# Altium schematic sheet Trigger.SchDoc(Trigger)
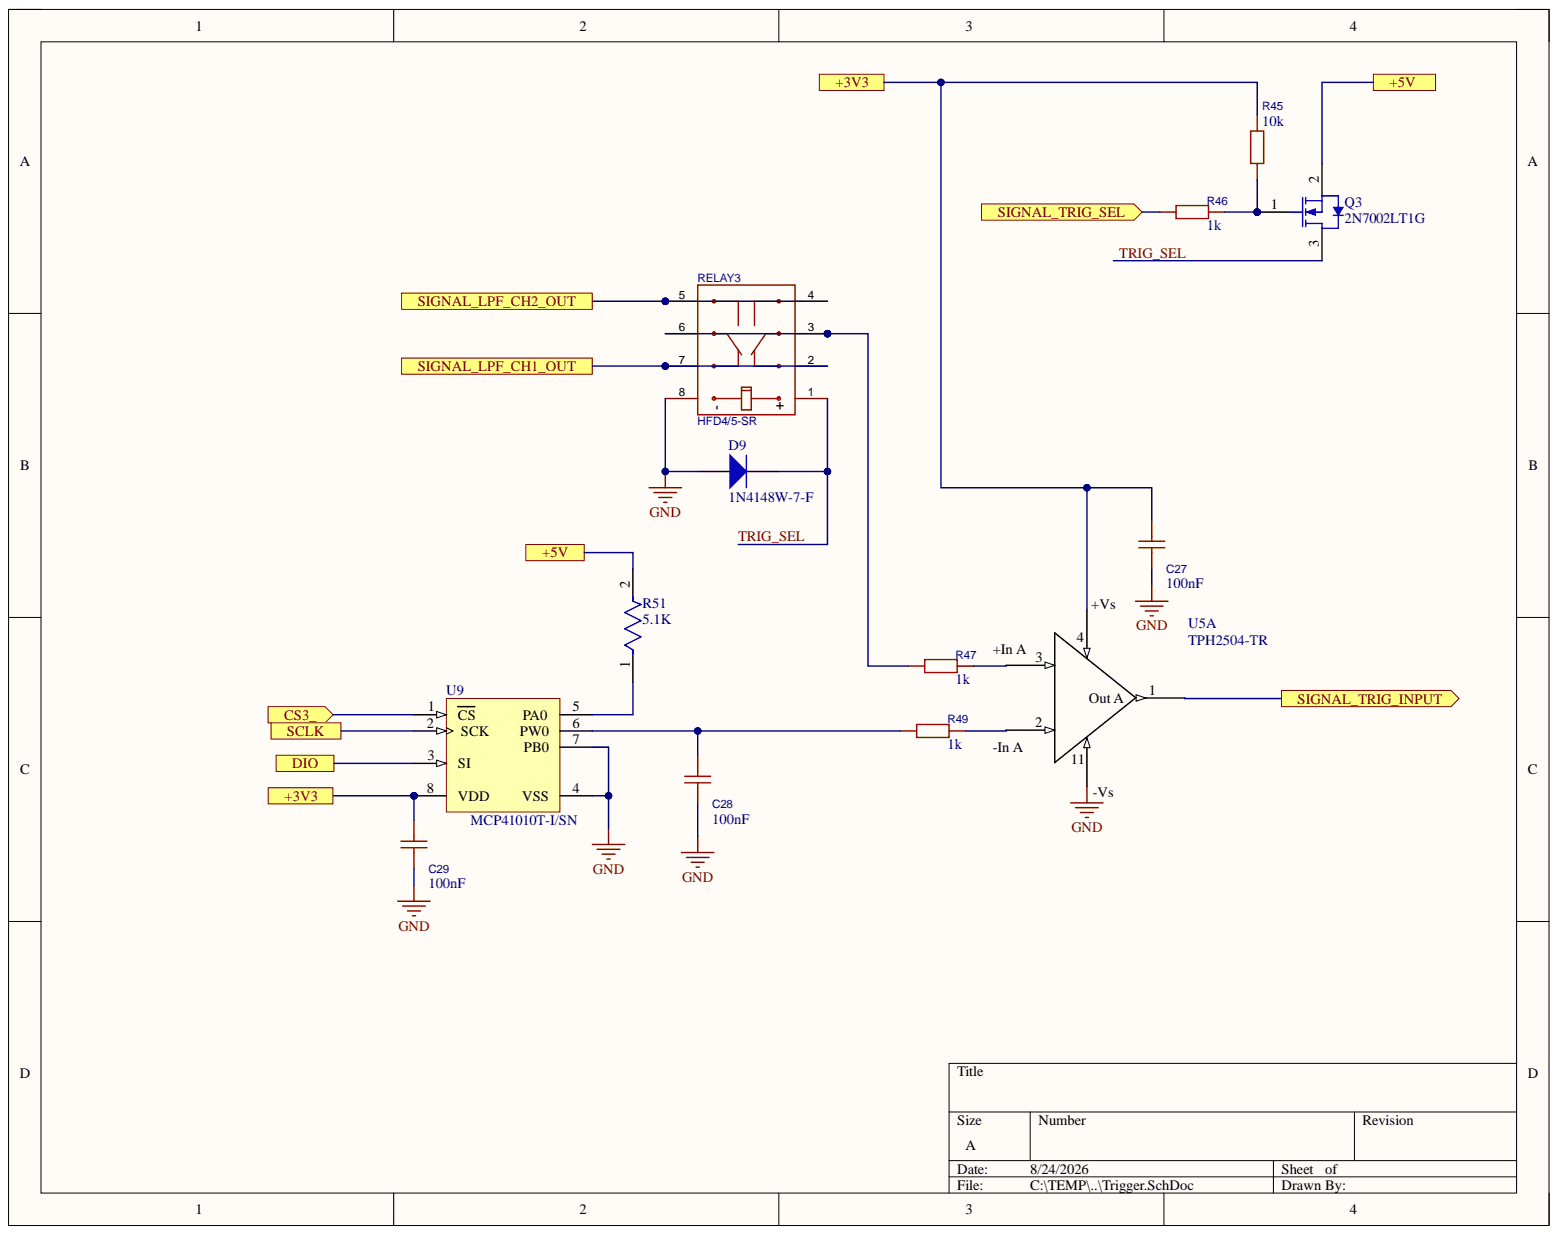
[diagram p0: Trigger.SchDoc]

## Parts
C27  value 100nF  [C0805]  (hidden comment: 0805CG6R0C500NT_C67560)
C28  value 100nF  [C0805]  (hidden comment: 0805CG6R0C500NT_C67560)
C29  value 100nF  [C0805]  (hidden comment: 0805CG6R0C500NT_C67560)
D9  1N4148W-7-F  [DIOD-SOD123-2_V]
Q3  2N7002LT1G  [ONSC-SOT-23-3-318-08_V]
R45  value 10k  [R0805]  (hidden comment: 0805W8F0000T5E_C17477)
R46  value 1k  [R0805]  (hidden comment: 0805W8F0000T5E_C17477)
R47  value 1k  [R0805]  (hidden comment: 0805W8F0000T5E_C17477)
R49  value 1k  [R0805]  (hidden comment: 0805W8F0000T5E_C17477)
R51  5.1K  [FP-RT0805-MFG]
RELAY3  HFD4/5-SR  [RELAY-SMD_HFD4-5-SR]
U5  TPH2504-TR  [TSOP65P640X120-14N]  (part A)
U9  MCP41010T-I/SN  [SOIC-SN8_M]

## Ports
+3V3, +5V, CS3_, DIO, SCLK, SIGNAL_LPF_CH1_OUT, SIGNAL_LPF_CH2_OUT, SIGNAL_TRIG_INPUT, SIGNAL_TRIG_SEL

## Nets
GND: C27.2 C28.2 C29.2 D9.1 RELAY3.8 U5.11 U9.4(VSS) U9.7(PB0)
+3V3: C27.1 C29.1 R45.2 U5.4 U9.8(VDD) ; port +3V3
+5V: Q3.2 R51.2 ; port +5V
CS3_: U9.1(CS) ; port CS3_
DIO: U9.3(SI) ; port DIO
SCLK: U9.2(SCK) ; port SCLK
SIGNAL_LPF_CH1_OUT: RELAY3.2 RELAY3.7 ; port SIGNAL_LPF_CH1_OUT
SIGNAL_LPF_CH2_OUT: RELAY3.4 RELAY3.5 ; port SIGNAL_LPF_CH2_OUT
SIGNAL_TRIG_INPUT: U5.1(Out_A) ; port SIGNAL_TRIG_INPUT
SIGNAL_TRIG_SEL: R46.1 ; port SIGNAL_TRIG_SEL
TRIG_SEL: D9.2 Q3.3 RELAY3.1
NetC28_1: C28.1 R49.1 U9.6(PW0)
NetQ3_1: Q3.1 R45.1 R46.2
NetR47_1: R47.1 RELAY3.3 RELAY3.6
NetR47_2: R47.2 U5.3
NetR49_2: R49.2 U5.2
NetR51_1: R51.1 U9.5(PA0)

## Library data of the parts
C27-C29 = CMP-015-000003-2: Capacitance:100nF Tolerance:±5% Tolerance:±5% Voltage Rating:50V Temperature Coefficient:X7R; Manufacturer: YAGEO(国巨); AddInBom: yes; Device: CC0805JRX7R9BB104; ModelId: 8c88e81f49c6409b980720ec8953afb6?0819f05c4eef4c71ace90d822a990e87; ModelName: C0805_L2.0-W1.3-H1.3; ModelTransform: 78.74,51.181,0,0,0,0,0,0.0005,0; Temperature Coefficient: X7R; Tolerance: 5%; Voltage Rating: 50V; Component Kind: Standard; ConvertToPcb: yes; Datasheet: https://item.szlcsc.com/datasheet/CC0805JRX7R9BB104/112720.html; Design Item ID: CC0805JRX7R9BB104; FootprintName: C0805; JLCPCB Part Class: Extended Part; LCSC Part Name: 100nF ±5% 50V; Manufacturer Part Number: CC0805JRX7R9BB104; Source: P1.SCHLIB; Supplier Footprint: 0805; Supplier Part Number: C111492; Symbol: CC0805JRX7R9BB104; Library Name: zhiming xue
D9 = CMP-2000-04932-2: Fast Switching Diode, 100 V, 0.15 A, -65 to 150 degC, 2-Pin?SOD123, RoHS, Tape and Reel; Manufacturer: Diodes Inc; Configuration: Single; If - Forward Current: 0.15A; Ir - Reverse Current: 1uA; Max Surge Current: 2A; Peak Reverse Voltage: 100V; Recovery Time: 4ns; Unit Weight: 0.000353oz; Vf - Forward Voltage: 1.25V; Component Kind: Standard; Datasheet URL: http://www.farnell.com/datasheets/1915529.pdf; Datasheet Version: Rev. 24-2, 10/2013; Factory Pack Quantity: 3000; Height: 1.25mm; Length: 2.85mm; Library Name: Altium Content Vault; Manufacturer URL: http://www.diodes.com/; Maximum Operating Temperature: +150C; Minimum Operating Temperature: -65C; Mounting Style: SMD/SMT; Operating Temperature Range: -65Cto+150C; Package / Case: SOD-123; Package Reference: SOD123-2; Package URL: http://www.diodes.com/datasheets/ds18004.pdf; Packaging: Reel; Product: SwitchingDiodes; Product Category: Diodes-GeneralPurpose,Power,Switching; RoHS: True; Series: 1N4148; Type: SwitchingDiode; Width: 1.7mm
Q3 = CMP-1058-00781-1: Small Signal MOSFET, 60 V, 115 mA, N-Channel, 3-Pin SOT-23, Pb-Free, Tape and Reel; Manufacturer: On Semiconductor; Channel Polarity: N Channel; Configuration: Single; ID Max (A): 0.115; V(BR)DSS Min (V): 60; VGS Max (V): 20; VGS(th) Max (V): 2.5; Component Kind: Standard; ComponentLink1Description: Manufacturer URL; ComponentLink1URL: http://www.onsemi.com/; ComponentLink2Description: Datasheet; ComponentLink2URL: http://www.onsemi.com/pub_link/Collateral/2N7002L-D.PDF; ComponentLink3Description: Package Specification; ComponentLink3URL: http://www.onsemi.cn/pub_link/Collateral/318-08.PDF; DatasheetVersion: Rev. 7; Library Name: Altium Content Vault; Mounting Technology: Surface Mount; Package Type: SOT-23-3; PackageDescription: 3-Pin Small Outline Transistor, Body 2.92 x 1.3 mm, Pitch 0.95 mm; PackageReference: SOT-23-3-318-08; PackageVersion: Rev. AP, 11/2009; Packing: Tape and Reel; PartNumber: 2N7002LT1G; RoHS: Pb-Free
R45 = CMP-016-000002-1: Type:Thick Film Resistor Resistance:10kΩ Tolerance:±1% Tolerance:±1% Power(Watts):125mW Voltage-Supply(Max):150V Temperature Coefficient:±100ppm/℃ Temperature Coefficient:±100ppm/℃ Operating Temperature:-55℃~+155℃ Operating Temperature:-55℃~+155℃; Manufacturer: UNI-ROYAL(厚声); AddInBom: yes; Device: 0805W8F1002T5E; ModelId: b83c7c5430874b8eb2319a65d9433429?0819f05c4eef4c71ace90d822a990e87; ModelName: R0805_L2.0-W1.3-H0.6; ModelTransform: 78.74,51.181,0,0,0,0,0,-0.0005,0; Power(Watts): 125mW; Tolerance: 1%; Voltage-Supply(Max): 150V; Component Kind: Standard; ConvertToPcb: yes; Datasheet: https://item.szlcsc.com/datasheet/0805W8F1002T5E/18102.html; Design Item ID: 0805W8F1002T5E; FootprintName: R0805; JLCPCB Part Class: Basic Part; LCSC Part Name: 10kΩ ±1% 125mW 厚?电?; Manufacturer Part Number: 0805W8F1002T5E; Operating Temperature: -55℃~+155℃; Source: P1.SCHLIB; Supplier Footprint: 0805; Supplier Part Number: C17414; Symbol: 0805W8F1002T5E; Temperature Coefficient: ±100ppm/℃; Type: Thick Film Resistor; Library Name: zhiming xue
R46 R47 R49 = CMP-016-000001-1: Type:Thick Film Resistors Resistance:1kΩ Tolerance:±1% Tolerance:±1% Power(Watts): Overload Voltage (Max): Temperature Coefficient:±100ppm/℃ Temperature Coefficient:±100ppm/℃ Operating Temperature Range:-55℃~+155℃ Operating Temperature Range:-55℃~+155℃; Manufacturer: UNI-ROYAL(厚声); AddInBom: yes; Device: 0805W8F1001T5E; ModelId: b83c7c5430874b8eb2319a65d9433429?0819f05c4eef4c71ace90d822a990e87; ModelName: R0805_L2.0-W1.3-H0.6; ModelTransform: 78.74,51.181,0,0,0,0,0,-0.0005,0; Tolerance: 1%; Component Kind: Standard; ConvertToPcb: yes; Datasheet: https://atta.szlcsc.com/upload/public/pdf/source/20200306/C422600_1E6D84923E4A46A82E41ADD87F860B5C.pdf; Design Item ID: 0805W8F1001T5E; FootprintName: R0805; JLCPCB Part Class: Basic Part; LCSC Part Name: 1kΩ ±1% 125mW 厚?电?; Manufacturer Part Number: 0805W8F1001T5E; Operating Temperature Range: -55℃~+155℃; Source: P1.SCHLIB; Supplier Footprint: 0805; Supplier Part Number: C17513; Symbol: 0805W8F1001T5E; Temperature Coefficient: ±100ppm/℃; Type: Thick Film Resistors; Library Name: zhiming xue
R51 = CMP-00006-1: 125mW Thin Film Resistor ±50ppm/℃ ±1% 5.1kΩ 0805 Chip Resistor - Surface Mount ROHS; Manufacturer Package Identifier: 0805 (2012 metric); Temperature Coefficient: 50ppm/°C; Voltage Rating: 150V; Case Code (Imperial): 0805; Case/Package: 0805; China RoHS: Non-Compliant; Component Kind: Standard; Composition: Thin Film; Contact Plating: Tin; Depth: 1.25mm; Height: 500µm; Lead Free: Lead Free; Length: 2mm; Lifecycle Status: Production; Max Operating Temperature: 155°C; Max Power Dissipation: 125mW; Military Standard: Not; Min Operating Temperature: -55°C; Mounting Technology: Surface Mount; Number of Terminals: 2; Number of Terminations: 2; Power Rating: 125mW; Radiation Hardening: No; REACH SVHC: Yes; RoHS: Compliant; Termination: SMD/SMT; Tolerance: 1%; Value: 5.1kΩ; Voltage Rating (DC): 150V; Width: 1.25mm; Working Voltage: 150V; Library Name: zhiming xue
RELAY3 = CMP-006-000000-2: Coil Voltage:5V Contact Form:2 Form C: 2C (DPDT-CO) Number of Pins:8 Contact Rating:1A@30VDC;300mA@125VAC Switching Voltage(Max):250V@AC;220V@DC Contact Material:AgNi + Gold plated Coil Rated Power:140mW Coil Resistance:178Ω Switching Current(Max):2A Oper; Manufacturer: HF(宏发); AddInBom: yes; Coil Rated Power: 140mW; Coil Resistance: 178Ω; Coil Type: Polarized-Single Coil; Coil Voltage: 5V; Contact Resistance: 100mΩ; ModelId: abc4320b17a04819849f22b1ebcd3fe7?0819f05c4eef4c71ace90d822a990e87; ModelName: RELAY-SMD_L10.0-W6.5-H5.7-LS7.5-P2.2; ModelTransform: 393.7,307.086,0,0,0,0,-0.005,0,0; Operate Time: 3ms; Switching Current(Max): 2A; Component Kind: Standard; Contact Form: 2 Form C: 2C (DPDT-CO); Contact Material: AgNi + Gold plated; Contact Rating: 1A@30VDC;300mA@125VAC; ConvertToPcb: yes; Datasheet: https://item.szlcsc.com/datasheet/HFD4%252F5-SR/65466.html; Design Item ID: HFD4/5-SR; Device: HFD4/5-SR; FootprintName: RELAY-SMD_HFD4-5-SR; JLCPCB Part Class: Extended Part; LCSC Part Name: ?小型5V继电器 双刀双掷; Manufacturer Part Number: HFD4/5-SR; Number of Pins: 8; Operating Temperature: -40℃~+85℃; Release Time: 3ms; Source: P1.SCHLIB; Supplier Footprint: SMD,10x6.5mm; Supplier Part Number: C64399; Switching Voltage(Max): 250V@AC;220V@DC; Symbol: HFD4/5-S; Library Name: zhiming xue
U5 = CMP-00002-9: Operational Amplifier, 4 Func, 5000uV Offset-Max, CMOS, PDSO14; Common Mode Rejection Ratio: 45dB; GAIN: 120Meg; Input Offset Voltage (Vos): 5mV; Max Supply Current: 48mA; Max Supply Voltage: 7V; Nominal Supply Voltage (DC): 3V; Number of Circuits: 4; Slew Rate: 160V/µs; Unity Gain Bandwidth Product: 120MHz; Case/Package: TSSOP; Component Kind: Standard; Height - Seated (Max): 1.2mm; Length: 4.96mm; Max Operating Temperature: 125°C; Min Operating Temperature: -40°C; Number of Terminals: 14; RoHS: Compliant; Temperature Grade: Automotive; Terminal Pitch: 650µm; Width: 4.4mm; Library Name: zhiming xue
U9 = CMP-0188-00466-2: Single/Dual Digital Potentiometer with SPI Interface, 8-Pin SOIC 150mil, Industrial Temperature, Tape and Reel; Code_IPC: SOIC127P600-8; Code_JEDEC: MS-012; Component Kind: Standard; ComponentLink1Description: Manufacturer URL; ComponentLink1URL: http://www.microchip.com/; ComponentLink2Description: Datasheet; ComponentLink2URL: http://ww1.microchip.com/downloads/en/DeviceDoc/11195c.pdf; ComponentLink3Description: Package Specification; ComponentLink3URL: http://www.microchip.com/stellent/groups/techpub_sg/documents/packagingspec/en012702.pdf; DatasheetVersion: Jul-2003; Library Name: Altium Content Vault; PackageDescription: 8-Lead Plastic Small Outline (SN) - Narrow, 3.90mm Body [SOIC]; PackageReference: SOIC-SN8; PackageVersion: revBB, Aug-2009; RevisionNote: URLs added.
